# Altium schematic sheet RTD.SchDoc
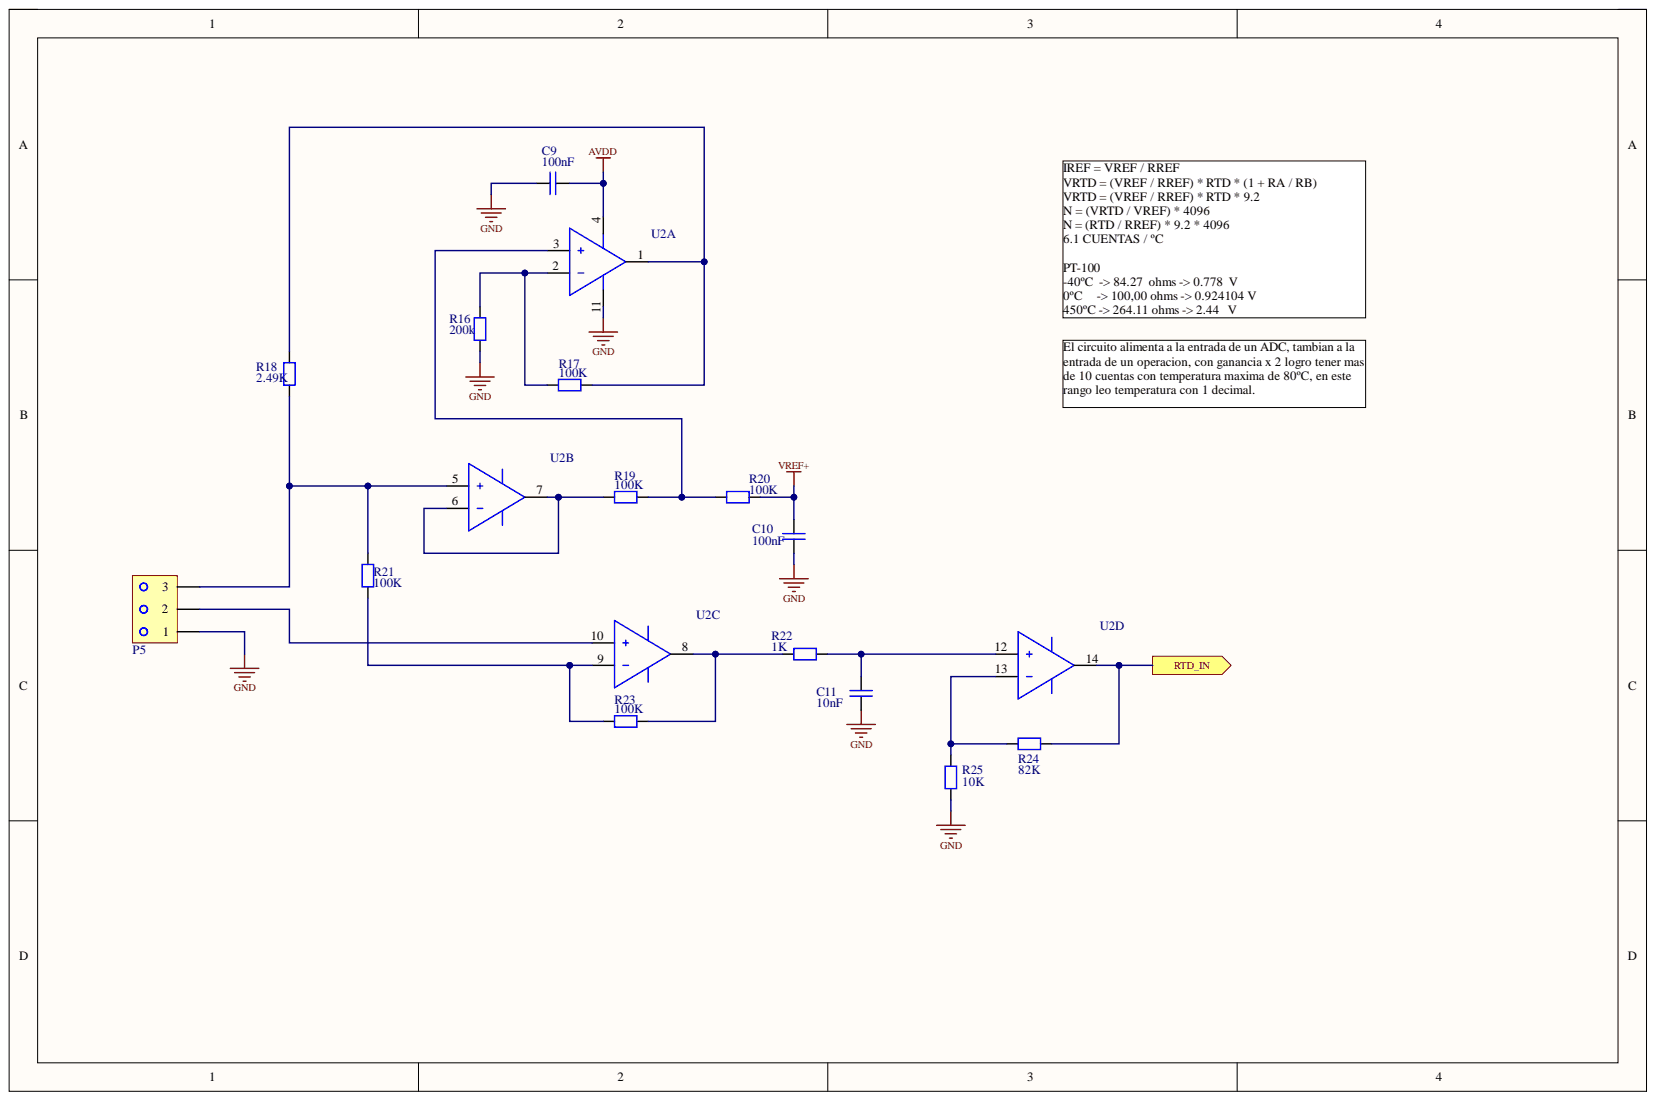
[diagram p0: RTD.SchDoc]

## Parts
C9  100nF  [CAP_SM0805_YAGEOCC2_N]
C10  100nF  [CAP_SM0805_YAGEOCC2_N]
C11  10nF  [CAP_SM0805_YAGEOCC2_N]
P5  WJ15EDGVC-3.81-3P  [HEADER PLUG 3]  (value not drawn)  (jumper)
R16  200k  [RES_SM0805_YAGEORC_N]
R17  100K  [RES_SM0805_YAGEORC_N]
R18  2.49K  [RES_SM0805_YAGEORC_N]
R19  100K  [RES_SM0805_YAGEORC_N]
R20  100K  [RES_SM0805_YAGEORC_N]
R21  100K  [RES_SM0805_YAGEORC_N]
R22  1K  [RES_SM0805_YAGEORC_N]
R23  100K  [RES_SM0805_YAGEORC_N]
R24  82K  [RES_SM0805_YAGEORC_N]
R25  10K  [RES_SM0805_YAGEORC_N]
U2  GS8044  [GS8044-SR]  (value not drawn)  (parts A,B,C,D)

## Ports
RTD_IN

## Nets
AVDD: C9.1 U2.4
GND: C9.2 C10.2 C11.2 P5.1 R16.1 R25.1 U2.11
VREF+: C10.1 R20.2
RTD_IN: R24.2 U2.14 ; port RTD_IN
NetC11_1: C11.1 R22.2 U2.12
NetP5_2: P5.2 U2.10
NetP5_3: P5.3 R18.1 R21.2 U2.5
NetR16_2: R16.2 R17.1 U2.2
NetR17_2: R17.2 R18.2 U2.1
NetR19_1: R19.1 U2.6 U2.7
NetR19_2: R19.2 R20.1 U2.3
NetR21_1: R21.1 R23.1 U2.9
NetR22_1: R22.1 R23.2 U2.8
NetR24_1: R24.1 R25.2 U2.13

## Library data of the parts
C9 C10 = CC0805KRX7R8BB104: CAP 100nF SM0805 X7R 25V YAGEO CC2; Tolerance (%): 10; Voltage Rating (Volts): 25; Category: Capacitor; Component Kind: Standard; ComponentLink1Description: Manufacturer Website; ComponentLink1URL: http://www.yageo.com; Library Name: Yageo.SchLib; Manufacturer: Yageo; Manufacturer Part Number: CC0805KRX7R8BB104; Package: 0805; Supplier 1: Farnell; Supplier 2: Digi-Key; Supplier Part Number 1: 644249; Supplier Part Number 2: 311-1141-1-ND; Value: 100nF
C11 = CC0805KRX7R8BB103: CAP 10nF SM0805 X7R 25V YAGEO CC2; Tolerance (%): 10; Voltage Rating (Volts): 25; Category: Capacitor; Component Kind: Standard; ComponentLink1Description: Manufacturer Website; ComponentLink1URL: http://www.yageo.com; Library Name: Yageo.SchLib; Manufacturer: Yageo; Manufacturer Part Number: CC0805KRX7R8BB103; Package: 0805; Supplier 1: Farnell; Supplier Part Number 1: 3019767; Value: 10nF
P5 = WJ15EDGVC-3.81-3P: TERM BLOK FEMALE 1X3 3.81MM; Manufacturer: Ningbo Kangnex Elec; Category: Bornera enchufable; Datasheet: https://datasheet.lcsc.com/lcsc/1912251632_Ningbo-Kangnex-Elec-WJ15EDGVC-3-81-3P_C8389.pdf; Library Name: plug.SchLib; Manufacturer Part Number: WJ15EDGVC-3.81-3P
R16 = RC0805FR-07200KL: RES 200K SM0805 YAGEO RC_L; Power (Watts): 0.1; Resistance (Ohms): 200000; Tolerance (%): 1; Category: Resistor; Component Kind: Standard; ComponentLink1Description: Manufacturer Website; ComponentLink1URL: http://www.yageo.com; Library Name: Yageo.SchLib; Manufacturer: Yageo; Manufacturer Part Number: RC0805FR-07200KL; Package: 0805; Value: 200k
R17 R19-R21 R23 = RC0805FR-07100KL: RES 100K SM0805 YAGEO RC_L; Power (Watts): 0.125; Resistance (Ohms): 100000; Tolerance (%): 1; Category: Resistor; Component Kind: Standard; ComponentLink1Description: Manufacturer Website; ComponentLink1URL: http://www.yageo.com; Library Name: Yageo.SchLib; Manufacturer: Yageo; Manufacturer Part Number: RC0805FR-07100KL; Package: 0805; Supplier 1: Farnell; Supplier 2: Digi-Key; Supplier Part Number 1: 9237879; Supplier Part Number 2: 311-100KCRCT-ND; Value: 100K
R18 = RT0805FRE072K49L: RES 2K49 SM0805 YAGEO RT_L; Manufacturer Part Number: RC0603FR-072K49L; Power (Watts): 0.1; Resistance (Ohms): 2490; Tolerance (%): 1; Category: Resistor; Component Kind: Standard; ComponentLink1Description: Manufacturer Website; ComponentLink1URL: http://www.yageo.com; Library Name: Yageo.SchLib; Manufacturer: Yageo; Package: 0805; Value: 2.49K
R22 = RC0805FR-071KL: RES 1K SM0805 YAGEO RC_L; Power (Watts): 0.125; Resistance (Ohms): 1000; Tolerance (%): 1; Category: Resistor; Component Kind: Standard; ComponentLink1Description: Manufacturer Website; ComponentLink1URL: http://www.yageo.com; Library Name: Yageo.SchLib; Manufacturer: Yageo; Manufacturer Part Number: RC0805FR-071KL; Package: 0805; Supplier 1: Farnell; Supplier 2: Digi-Key; Supplier Part Number 1: 9237496; Supplier Part Number 2: 311-1.00KCRCT-ND; Value: 1K
R24 = RC0805FR-0782KL: RES 82K SM0805 YAGEO RC_L; Power (Watts): 0.125; Resistance (Ohms): 82000; Tolerance (%): 1; Category: Resistor; Component Kind: Standard; ComponentLink1Description: Manufacturer Website; ComponentLink1URL: http://www.yageo.com; Library Name: Yageo.SchLib; Manufacturer: Yageo; Manufacturer Part Number: RC0805FR-0782KL; Package: 0805; Supplier 1: Farnell; Supplier 2: Digi-Key; Supplier Part Number 1: 9237860; Supplier Part Number 2: 311-82.0KCRCT-ND; Value: 82K
R25 = RC0805FR-0710KL: RES 10K SM0805 YAGEO RC_L; Power (Watts): 0.125; Resistance (Ohms): 10000; Tolerance (%): 1; Category: Resistor; Component Kind: Standard; ComponentLink1Description: Manufacturer Website; ComponentLink1URL: http://www.yageo.com; Library Name: Yageo.SchLib; Manufacturer: Yageo; Manufacturer Part Number: RC0805FR-0710KL; Package: 0805; Supplier 1: Farnell; Supplier 2: Digi-Key; Supplier Part Number 1: 9237755; Supplier Part Number 2: 311-10.0KCRCT-ND; Value: 10K
U2 = GS8044-SR: IC OPAMP GP 4 CIRCUIT 14SOIC; Manufacturer: Gainsil; Category: Amplificador operacional; Component Kind: Standard; Datasheet: https://datasheet.lcsc.com/lcsc/2206101816_Gainsil-GS8044-SR_C605428.pdf; Library Name: OP_Amps.SchLib; Manufacturer Part Number: GS8044-SR
